# Altium schematic sheet 06cpu_soc.SchDoc
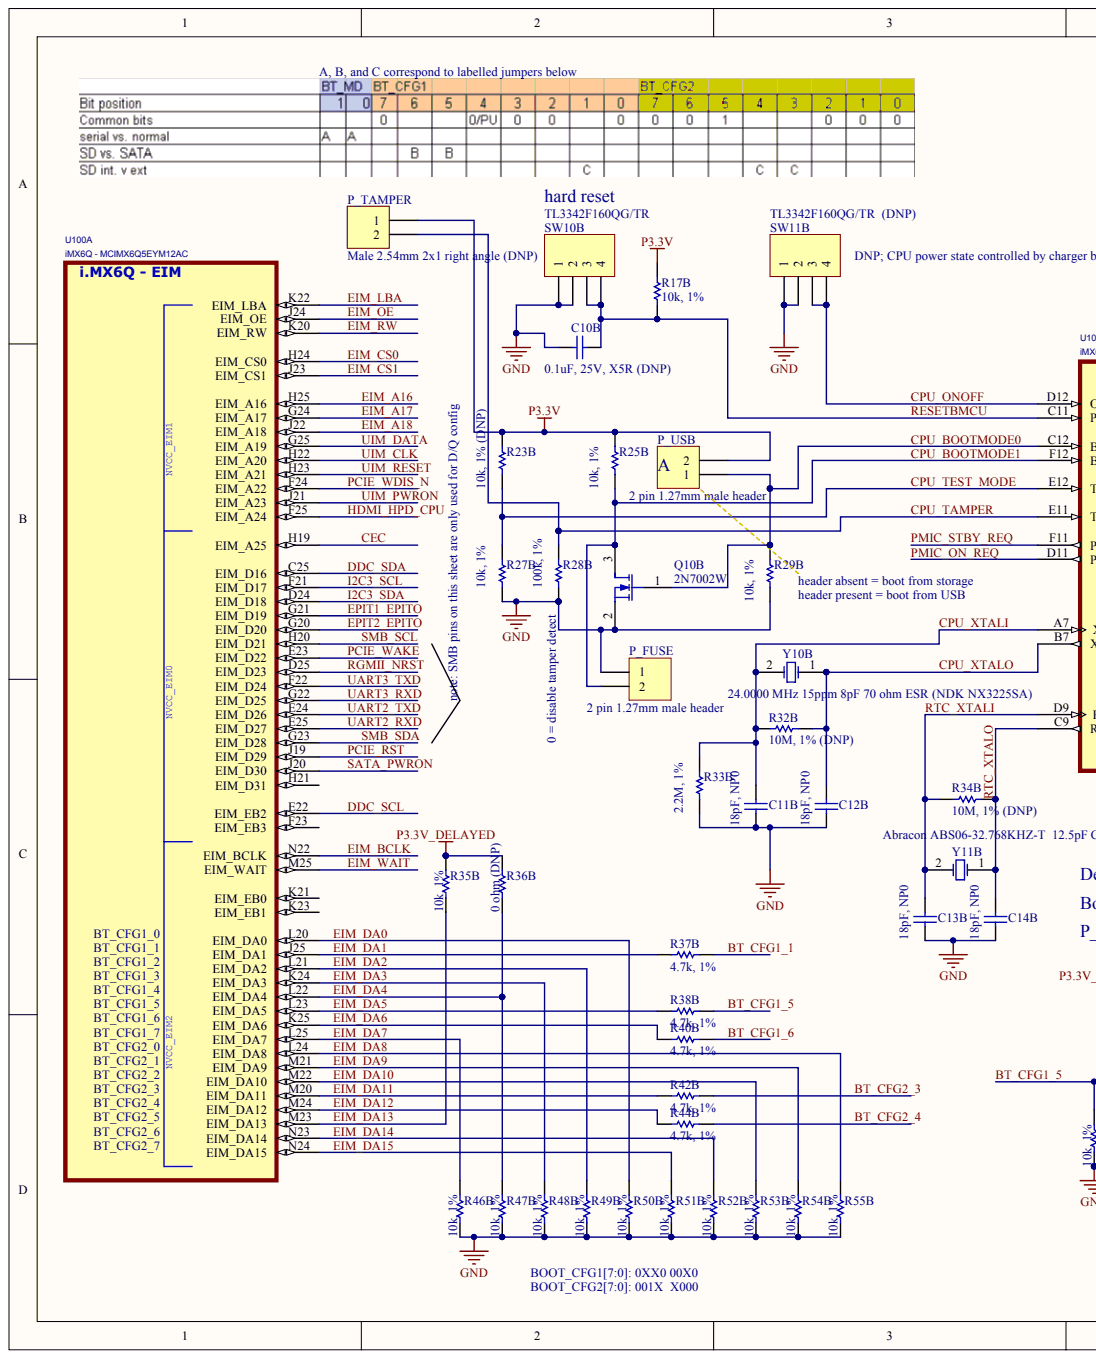
[diagram p0: 06cpu_soc.SchDoc, left]
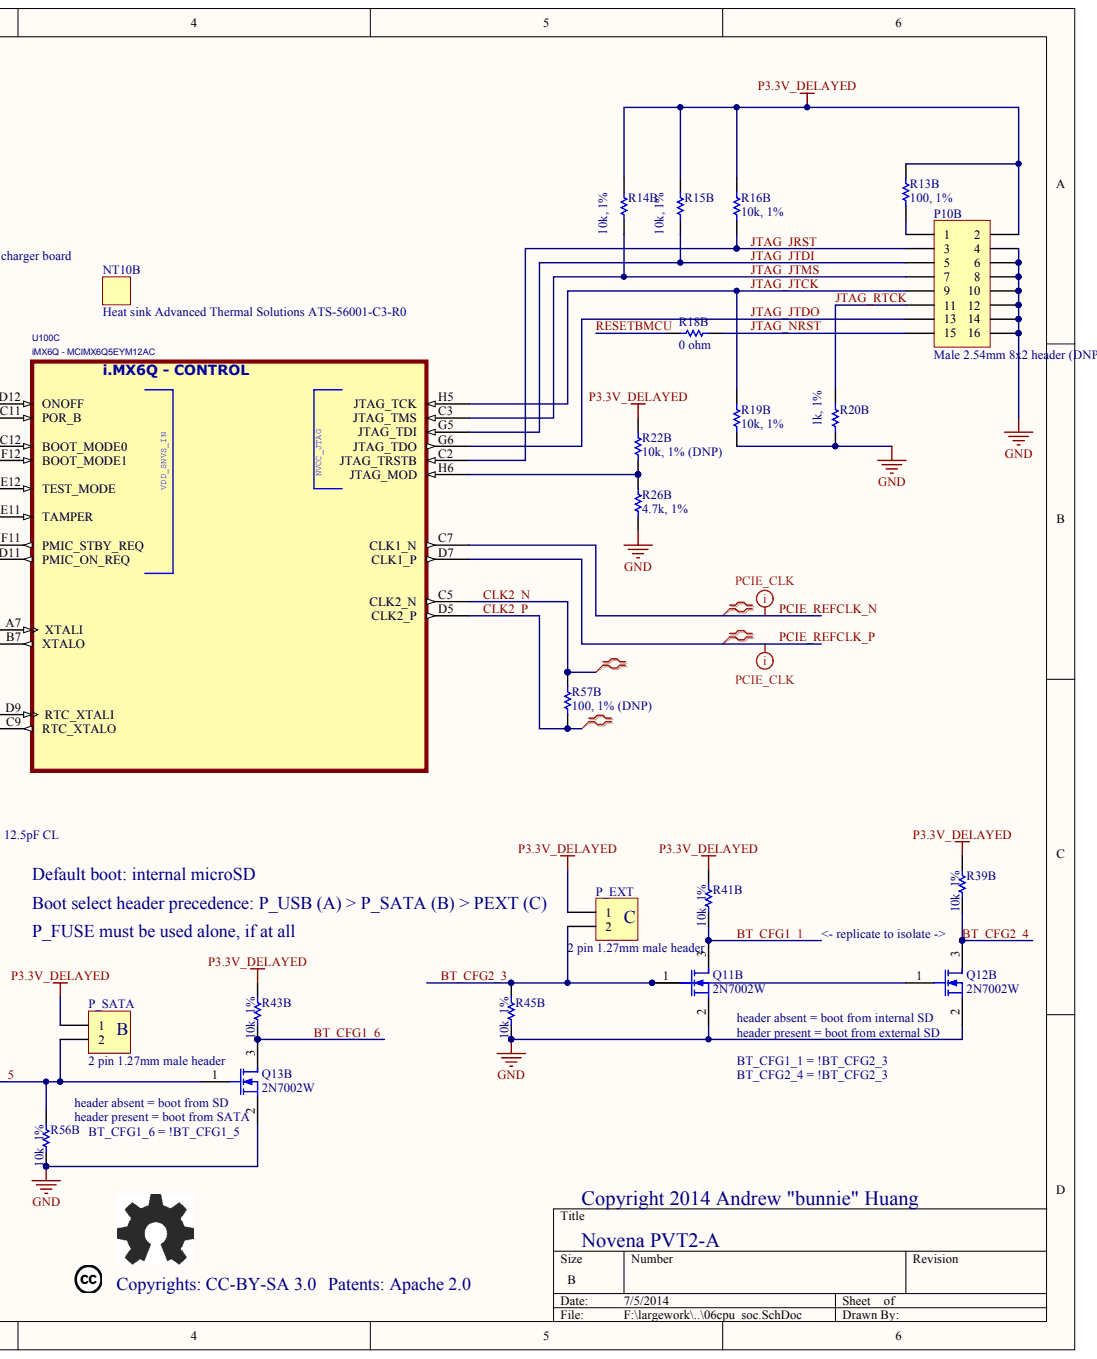
[diagram p1: 06cpu_soc.SchDoc, right]

## Parts
C10B  0.1uF, 25V, X5R (DNP)
C11B  18pF, NP0
C12B  18pF, NP0
C13B  18pF, NP0
C14B  18pF, NP0
NT10B  Heat sink Advanced Thermal Solutions ATS-56001-C3-R0
P10B  Male 2.54mm 8x2 header (DNP)
P_EXT  2 pin 1.27mm male header
P_FUSE  2 pin 1.27mm male header
P_SATA  2 pin 1.27mm male header
P_TAMPER  Male 2.54mm 2x1 right angle (DNP)
P_USB  2 pin 1.27mm male header
Q10B  2N7002W
Q11B  2N7002W
Q12B  2N7002W
Q13B  2N7002W
R13B  100, 1%
R14B  10k, 1%
R15B  10k, 1%
R16B  10k, 1%
R17B  10k, 1%
R18B  0 ohm
R19B  10k, 1%
R20B  1k, 1%
R22B  10k, 1% (DNP)
R23B  10k, 1% (DNP)
R25B  10k, 1%
R26B  4.7k, 1%
R27B  10k, 1%
R28B  100k, 1%
R29B  10k, 1%
R32B  10M, 1% (DNP)
R33B  2.2M, 1%
R34B  10M, 1% (DNP)
R35B  10k, 1%
R36B  0 ohm (DNP)
R37B  4.7k, 1%
R38B  4.7k, 1%
R39B  10k, 1%
R40B  4.7k, 1%
R41B  10k, 1%
R42B  4.7k, 1%
R43B  10k, 1%
R44B  4.7k, 1%
R45B  10k, 1%
R46B  10k, 1%
R47B  10k, 1%
R48B  10k, 1%
R49B  10k, 1%
R50B  10k, 1%
R51B  10k, 1%
R52B  10k, 1%
R53B  10k, 1%
R54B  10k, 1%
R55B  10k, 1%
R56B  10k, 1%
R57B  100, 1% (DNP)
SW10B  TL3342F160QG/TR
SW11B  TL3342F160QG/TR  (DNP)
U100  iMX6Q - MCIMX6Q5EYM12AC  (parts A,C)
Y10B  24.0000 MHz 15ppm 8pF 70 ohm ESR (NDK NX3225SA)
Y11B  Abracon ABS06-32.768KHZ-T  12.5pF CL

## Nets
GND: C10B.2 C11B.2 C12B.2 C13B.2 C14B.2 P10B.4 P10B.6 P10B.8 P10B.10 P10B.12 P10B.14 P10B.16 P_FUSE.1 Q10B.2 Q11B.2 Q12B.2 Q13B.2 R19B.1 R20B.1 R26B.1 R27B.1 R28B.1 R29B.1 R33B.2 R45B.2 R46B.2 R47B.2 R48B.2 R49B.2 R50B.2 R51B.2 R52B.2 R53B.2 R54B.2 R55B.2 R56B.2 SW10B.1 SW10B.2 SW11B.1 SW11B.2
P3.3V: P_TAMPER.1 P_USB.2 R17B.2 R23B.2 R25B.2
P3.3V_DELAYED: P10B.2 P_EXT.1 P_SATA.1 R13B.2 R14B.2 R15B.2 R16B.2 R22B.2 R35B.1 R36B.1 R39B.1 R41B.1 R43B.1
BT_CFG1_1: Q11B.3 R37B.2 R41B.2
BT_CFG1_5: P_SATA.2 Q13B.1 R38B.2 R56B.1
BT_CFG1_6: Q13B.3 R40B.2 R43B.2
BT_CFG2_3: P_EXT.2 Q11B.1 Q12B.1 R42B.2 R45B.1
BT_CFG2_4: Q12B.3 R39B.2 R44B.2
CEC: U100.H19(EIM_A25)
CLK2_N: R57B.2 U100.C5(CLK2_N)
CLK2_P: R57B.1 U100.D5(CLK2_P)
CPU_BOOTMODE0: P_USB.1 Q10B.1 R29B.2 U100.C12(BOOT_MODE0)
CPU_BOOTMODE1: P_FUSE.2 Q10B.3 R25B.1 U100.F12(BOOT_MODE1)
CPU_ONOFF: SW11B.3 SW11B.4 U100.D12(ONOFF)
CPU_TAMPER: P_TAMPER.2 R28B.2 U100.E11(TAMPER)
CPU_TEST_MODE: R23B.1 R27B.2 U100.E12(TEST_MODE)
CPU_XTALI: C11B.1 R32B.1 R33B.1 U100.A7(XTALI) Y10B.2
CPU_XTALO: C12B.1 R32B.2 U100.B7(XTALO) Y10B.1
DDC_SCL: U100.E22(EIM_EB2)
DDC_SDA: U100.C25(EIM_D16)
EIM_A16: U100.H25(EIM_A16)
EIM_A17: U100.G24(EIM_A17)
EIM_A18: U100.J22(EIM_A18)
EIM_BCLK: U100.N22(EIM_BCLK)
EIM_CS0: U100.H24(EIM_CS0)
EIM_CS1: U100.J23(EIM_CS1)
EIM_DA0: R50B.1 U100.L20(EIM_DA0)
EIM_DA1: R37B.1 U100.J25(EIM_DA1)
EIM_DA2: R49B.1 U100.L21(EIM_DA2)
EIM_DA3: R48B.1 U100.K24(EIM_DA3)
EIM_DA4: R36B.2 R47B.1 U100.L22(EIM_DA4)
EIM_DA5: R38B.1 U100.L23(EIM_DA5)
EIM_DA6: R40B.1 U100.K25(EIM_DA6)
EIM_DA7: R46B.1 U100.L25(EIM_DA7)
EIM_DA8: R55B.1 U100.L24(EIM_DA8)
EIM_DA9: R54B.1 U100.M21(EIM_DA9)
EIM_DA10: R53B.1 U100.M22(EIM_DA10)
EIM_DA11: R42B.1 U100.M20(EIM_DA11)
EIM_DA12: R44B.1 U100.M24(EIM_DA12)
EIM_DA13: R35B.2 U100.M23(EIM_DA13)
EIM_DA14: R52B.1 U100.N23(EIM_DA14)
EIM_DA15: R51B.1 U100.N24(EIM_DA15)
EIM_LBA: U100.K22(EIM_LBA)
EIM_OE: U100.J24(EIM_OE)
EIM_RW: U100.K20(EIM_RW)
EIM_WAIT: U100.M25(EIM_WAIT)
EPIT1_EPITO: U100.G21(EIM_D19)
EPIT2_EPITO: U100.G20(EIM_D20)
HDMI_HPD_CPU: U100.F25(EIM_A24)
I2C3_SCL: U100.F21(EIM_D17)
I2C3_SDA: U100.D24(EIM_D18)
JTAG_JRST: P10B.3 R16B.1 U100.C2(JTAG_TRSTB)
JTAG_JTCK: P10B.9 R19B.2 U100.H5(JTAG_TCK)
JTAG_JTDI: P10B.5 R15B.1 U100.G5(JTAG_TDI)
JTAG_JTDO: P10B.13 U100.G6(JTAG_TDO)
JTAG_JTMS: P10B.7 R14B.1 U100.C3(JTAG_TMS)
JTAG_NRST: P10B.15 R18B.1
JTAG_RTCK: P10B.11 R20B.2
PCIE_REFCLK_N: U100.C7(CLK1_N)
PCIE_REFCLK_P: U100.D7(CLK1_P)
PCIE_RST: U100.J19(EIM_D29)
PCIE_WAKE: U100.E23(EIM_D22)
PCIE_WDIS_N: U100.F24(EIM_A22)
PMIC_ON_REQ: U100.D11(PMIC_ON_REQ)
PMIC_STBY_REQ: U100.F11(PMIC_STBY_REQ)
RESETBMCU: C10B.1 R17B.1 R18B.2 SW10B.3 SW10B.4 U100.C11(POR_B)
RGMII_NRST: U100.D25(EIM_D23)
RTC_XTALI: C13B.1 R34B.1 U100.D9(RTC_XTALI) Y11B.2
RTC_XTALO: C14B.1 R34B.2 U100.C9(RTC_XTALO) Y11B.1
SATA_PWRON: U100.J20(EIM_D30)
SMB_SCL: U100.H20(EIM_D21)
SMB_SDA: U100.G23(EIM_D28)
UART2_RXD: U100.E25(EIM_D27)
UART2_TXD: U100.E24(EIM_D26)
UART3_RXD: U100.G22(EIM_D25)
UART3_TXD: U100.F22(EIM_D24)
UIM_CLK: U100.H22(EIM_A20)
UIM_DATA: U100.G25(EIM_A19)
UIM_PWRON: U100.J21(EIM_A23)
UIM_RESET: U100.H23(EIM_A21)
NetP10B_1: P10B.1 R13B.1
NetR22B_1: R22B.1 R26B.2 U100.H6(JTAG_MOD)

Not connected: U100 F23(EIM_EB3) H21(EIM_D31) K21(EIM_EB0) K23(EIM_EB1)

## Library data of the parts
R13B R14B R15B R16B R17B R18B R19B R20B R22B R23B R25B R26B R27B R29B R32B R33B R34B R35B R36B R37B R38B R39B R40B R41B R42B R43B R44B R45B R46B R47B R48B R49B R50B R51B R52B R53B R54B R55B R56B R57B = (no symbol name): Value: 100k; Published: 8-Jun-2000; LatestRevisionDate: 17-Jul-2002; LatestRevisionNote: Re-released for DXP Platform.; PackageReference: AXIAL-0.3; Publisher: Altium Limited
U100 = (no symbol name): AGILE_PN: TMP-WF-14526; MFG_NAME01: Freescale Semiconductor; MFG_PN01: PCIMX6Q6VV1A; PRE_APN: 344-01218; ROHS: Yes; HEIGHT: 2.16MM
C10B C11B C12B C13B C14B NT10B P10B P_EXT P_FUSE P_SATA P_TAMPER P_USB Q10B Q11B Q12B Q13B R28B SW10B SW11B Y10B Y11B = (no symbol name)
  C10B C11B C12B C13B C14B: Published: 8-Jun-2000; LatestRevisionDate: 17-Jul-2002; LatestRevisionNote: Re-released for DXP Platform.; PackageReference: C1206
  P10B P_EXT P_FUSE P_SATA P_TAMPER P_USB SW10B SW11B: LatestRevisionDate: 17-Jul-2002; LatestRevisionNote: Re-released for DXP Platform.
  Q10B Q11B Q12B Q13B: Published: 8-Jun-2000; LatestRevisionDate: 17-Jul-2002; LatestRevisionNote: Re-released for DXP Platform.; PackageVersion: 1995/1996; PackageReference: E3
  R28B: Published: 8-Jun-2000; LatestRevisionDate: 17-Jul-2002; LatestRevisionNote: Re-released for DXP Platform.; PackageReference: AXIAL-0.3; Value: 100k
  Y10B Y11B: Published: 8-Jun-2000; LatestRevisionDate: 17-Jul-2002; LatestRevisionNote: Re-released for DXP Platform.; PackageReference: R38
  C10B C11B C12B C13B C14B P10B P_EXT P_FUSE P_SATA P_TAMPER P_USB Q10B Q11B Q12B Q13B R28B SW10B SW11B Y10B Y11B: Publisher: Altium Limited
